# Altium schematic sheet AHRS.SchDoc
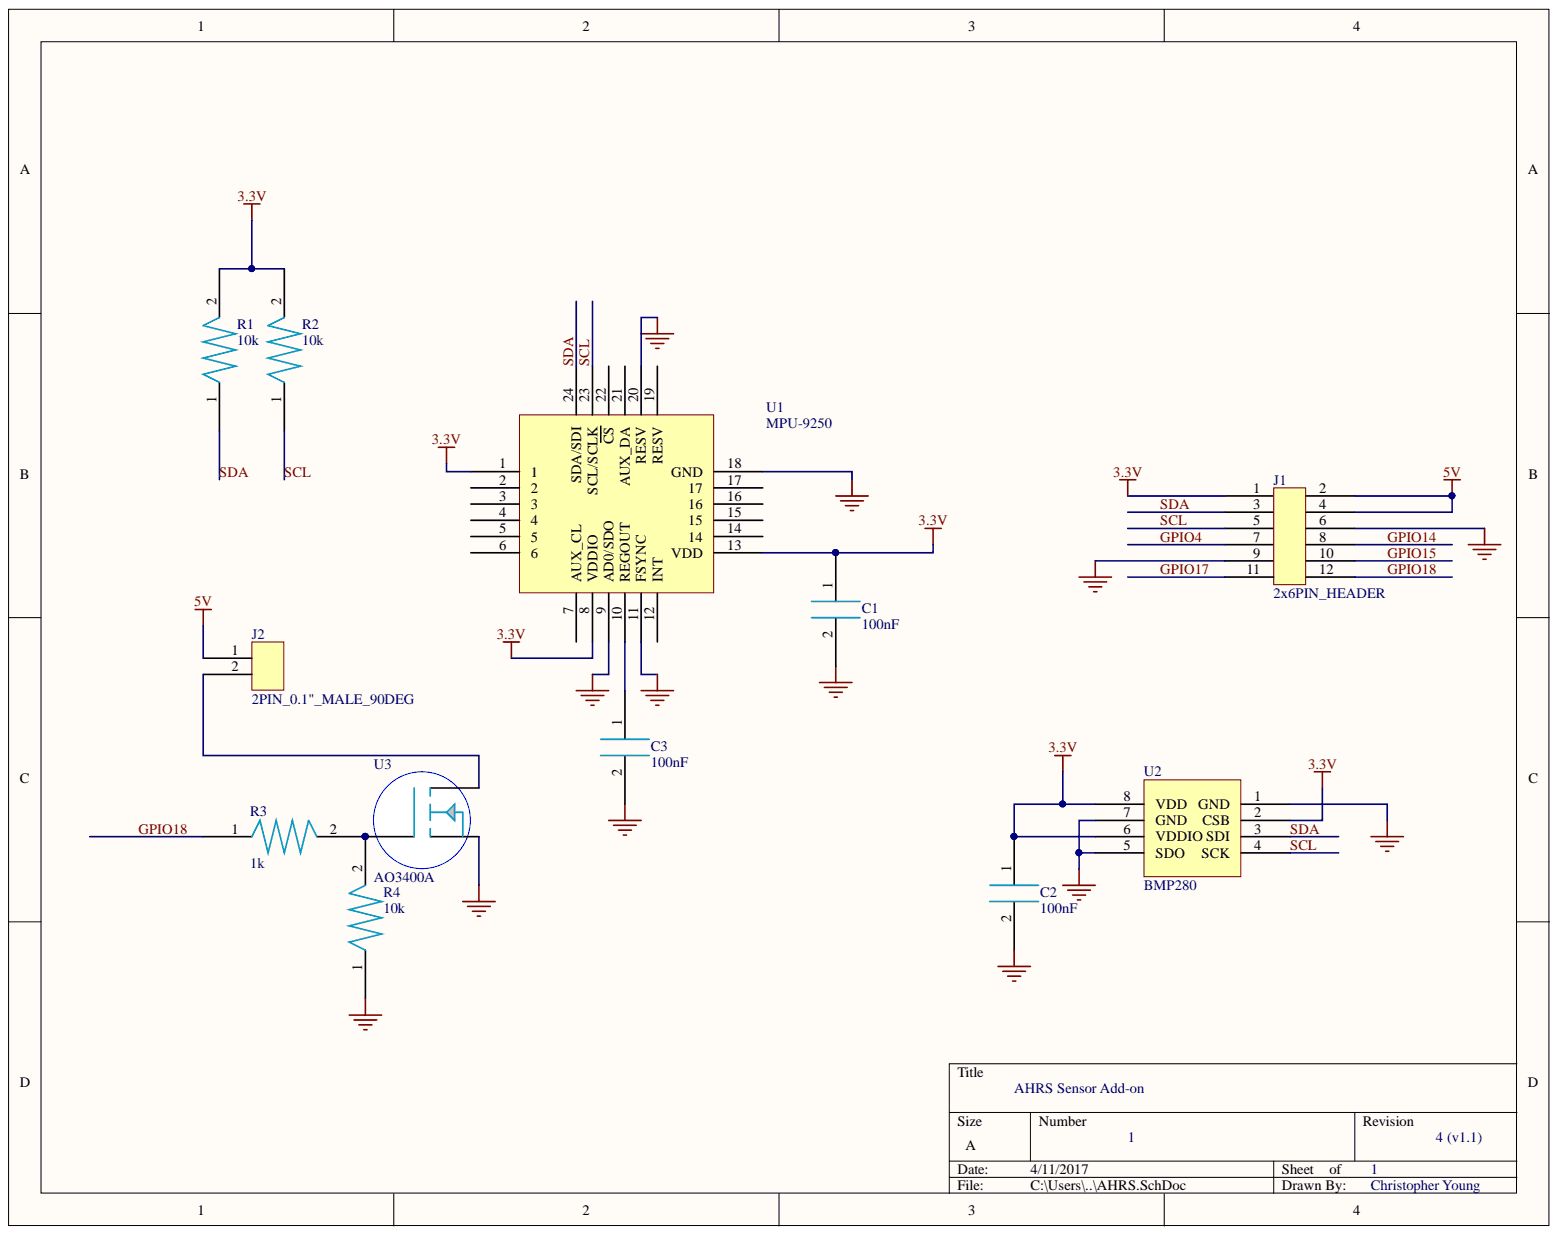
[diagram p0: AHRS.SchDoc]

## Parts
C1  100nF  [0603 CAP]
C2  100nF  [0603 CAP]
C3  100nF  [0603 CAP]
J1  2x6PIN_HEADER  [2x6PIN_HEADER]
J2  2PIN_0.1"_MALE_90DEG  [2PIN_0.1"_MALE_90DEG]
R1  10k  [0603 RES]
R2  10k  [0603 RES]
R3  1k  [0603 RES]
R4  10k  [0603 RES]
U1  MPU-9250  [MPU9250-QFN-24_0.4mm_3x3]
U2  BMP280  [LGA-8_BMP280(Primary)]
U3  AO3400A  [SOT23]

## Nets
3.3V: C1.1 C2.1 J1.1 R1.2 R2.2 U1.1 U1.8(VDDIO) U1.13(VDD) U2.2(CSB) U2.6(VDDIO) U2.8(VDD)
5V: J1.2 J1.4 J2.1
GND: C1.2 C2.2 C3.2 J1.6 J1.9 R4.1 U1.9(AD0/SDO) U1.11(FSYNC) U1.18(GND) U1.20(RESV) U2.1(GND) U2.5(SDO) U2.7(GND) U3.2
GPIO4: J1.7
GPIO14: J1.8
GPIO15: J1.10
GPIO17: J1.11
GPIO18: J1.12 R3.1
SCL: J1.5 R2.1 U1.23(SCL/SCLK) U2.4(SCK)
SDA: J1.3 R1.1 U1.24(SDA/SDI) U2.3(SDI)
NetC3_1: C3.1 U1.10(REGOUT)
NetJ2_2: J2.2 U3.3
NetR3_2: R3.2 R4.2 U3.1

Not connected: U1 2 3 4 5 6 7(AUX_CL) 12(INT) 14 15 16 17 19(RESV) 21(AUX_DA) 22(CS)

## Library data of the parts
C1-C3 = CAP: Component Kind: Standard; Description: 100nF; Library Name: AHRS.SchLib
R1-R4 = RES: Component Kind: Standard; Library Name: AHRS.SchLib
  R1 R2 R4: Description: 10k
  R3: Description: 1k
U1 = MPU-9250: IMU ACCEL/GYRO/MAG I2C/SPI 24QFN; Manufacturer: InvenSense; Output Type: I²C, SPI; Sensor Type: Accelerometer, Gyroscope, Magnetometer, 3 Axis; Category: Sensors, Transducers; Component Kind: Standard; ComponentLink1Description: http://store.invensense.com/datasheets/invensense/MPU9250REV1.0.pdf; ComponentLink1URL: http://store.invensense.com/datasheets/invensense/MPU9250REV1.0.pdf; Manufacturer Part Number: MPU-9250; Operating Temperature: -40°C ~ 85°C (TA); Part Status: Active; Pricing 1: 5000=4.51402 (USD); RoHS: RoHS Compliant; Stock 1: 95000; Supplier 1: Digi-Key; Library Name: AHRS.SchLib
U2 = BMP280: SENSOR PRESSURE ABS; Manufacturer: Bosch Sensortec; Accuracy: ±0.0145 PSI (±0.1 kPa); Maximum Pressure: 290.08 PSI (2000 kPa); Operating Pressure: 4.35 PSI ~ 15.95 PSI (30 kPa ~ 110 kPa); Output: 16b; Output Type: I²C, SPI; Pressure Type: Vented Gauge; Voltage - Supply: 1.71 V ~ 3.6 V; Category: Sensors, Transducers; Component Kind: Standard; ComponentLink1Description: https://ae-bst.resource.bosch.com/media/_tech/media/datasheets/BST-BMP280-DS001-12.pdf; ComponentLink1URL: https://ae-bst.resource.bosch.com/media/_tech/media/datasheets/BST-BMP280-DS001-12.pdf; Features: Standby Mode; Manufacturer Part Number: BMP280; Operating Temperature: -40°C ~ 85°C; Package / Case: 8-SMD; Part Status: Active; Port Size: -; Port Style: -; Pricing 1: 1=3.52, 5=3.102, 10=2.74, 25=2.2232, 50=1.9646, 100=1.9129, 500=1.6027, 1000=1.4993, 5000=1.3959 (USD); RoHS: RoHS Compliant; Stock 1: 7597; Supplier 1: Digi-Key; Supplier Device Package: 8-SMD; Termination Style: SMD (SMT) Tab; Library Name: AHRS.SchLib
J1 = 2x6PIN_HEADER: Component Kind: Standard; Library Name: AHRS.SchLib
J2 = 2PIN_0.1"_MALE_90DEG: Component Kind: Standard; Library Name: AHRS.SchLib
U3 = AO3400A: Component Kind: Standard; Library Name: AHRS.SchLib
